# Altium schematic sheet Ribbon Interface.SchDoc("Ribbon Interface")
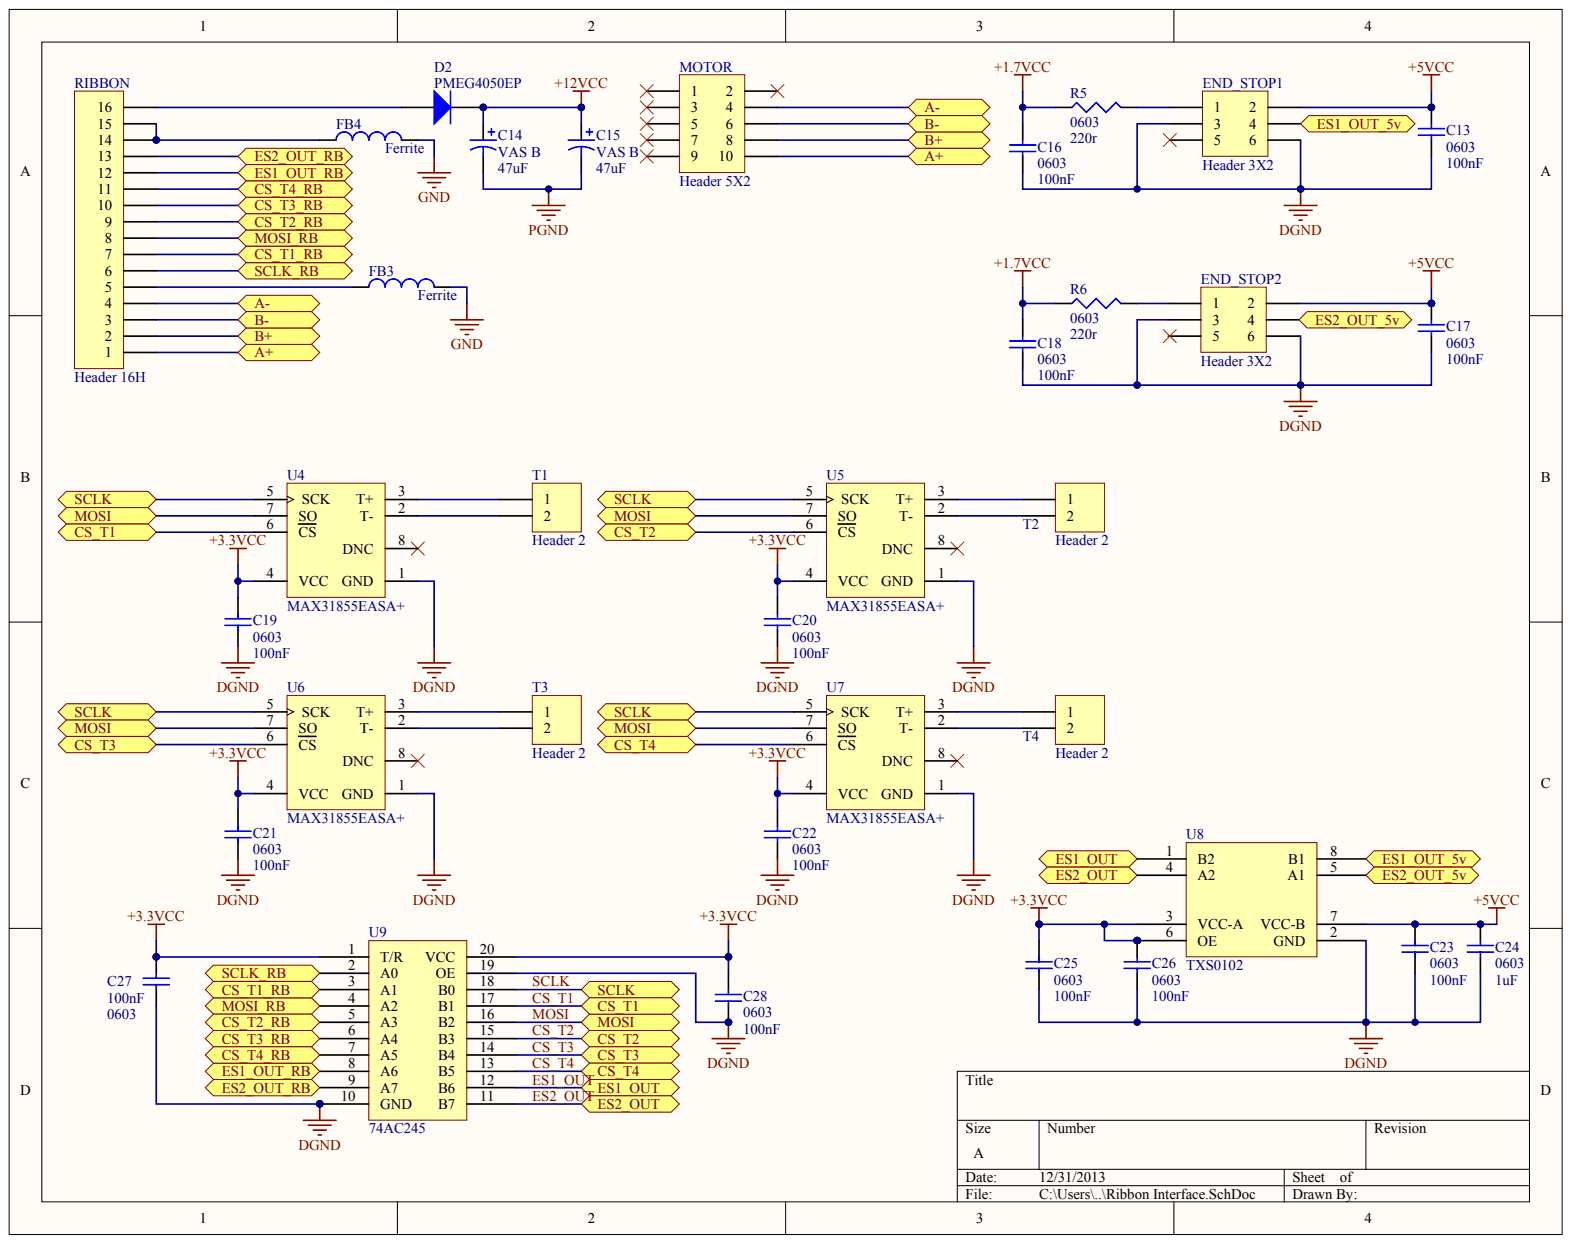
[diagram p0: Ribbon Interface.SchDoc]

## Parts
C13  0603  value 100nF
C14  VAS B  value 47uF
C15  VAS B  value 47uF
C16  0603  value 100nF
C17  0603  value 100nF
C18  0603  value 100nF
C19  0603  value 100nF
C20  0603  value 100nF
C21  0603  value 100nF
C22  0603  value 100nF
C23  0603  value 100nF
C24  0603  value 1uF
C25  0603  value 100nF
C26  0603  value 100nF
C27  0603  value 100nF
C28  0603  value 100nF
D2  PMEG4050EP
END_STOP1  Header 3X2
END_STOP2  Header 3X2
FB3  Ferrite
FB4  Ferrite
MOTOR  Header 5X2
R5  0603  value 220r
R6  0603  value 220r
RIBBON  Header 16H
T1  Header 2
T2  Header 2
T3  Header 2
T4  Header 2
U4  MAX31855EASA+
U5  MAX31855EASA+
U6  MAX31855EASA+
U7  MAX31855EASA+
U8  TXS0102
U9  74AC245

## Ports
A+, A-, B+, B-, CS_T1, CS_T1_RB, CS_T2, CS_T2_RB, CS_T3, CS_T3_RB, CS_T4, CS_T4_RB, ES1_OUT, ES1_OUT_5V, ES1_OUT_RB, ES2_OUT, ES2_OUT_5V, ES2_OUT_RB, MOSI, MOSI_RB, SCLK, SCLK_RB

## Nets
+1.7VCC: C16.2 C18.2 R5.1 R6.1
+3.3VCC: C19.2 C20.2 C21.2 C22.2 C25.2 C26.2 C27.2 C28.2 U4.4(VCC) U5.4(VCC) U6.4(VCC) U7.4(VCC) U8.3(VCC-A) U8.6(OE) U9.1(T/R) U9.20(VCC)
+5VCC: C13.2 C17.2 C23.2 C24.2 END_STOP1.2 END_STOP2.2 U8.7(VCC-B)
+12VCC: C14.1 C15.1 D2.1
DGND: C13.1 C16.1 C17.1 C18.1 C19.1 C20.1 C21.1 C22.1 C23.1 C24.1 C25.1 C26.1 C27.1 C28.1 END_STOP1.3 END_STOP1.6 END_STOP2.3 END_STOP2.6 U4.1(GND) U5.1(GND) U6.1(GND) U7.1(GND) U8.2(GND) U9.10(GND) U9.19(OE)
GND: FB3.2 FB4.2
PGND: C14.2 C15.2
CS_T1: U4.6(CS) U9.17(B1) ; port CS_T1
CS_T1_RB: RIBBON.7 U9.3(A1) ; port CS_T1_RB
CS_T2: U5.6(CS) U9.15(B3) ; port CS_T2
CS_T2_RB: RIBBON.9 U9.5(A3) ; port CS_T2_RB
CS_T3: U6.6(CS) U9.14(B4) ; port CS_T3
CS_T3_RB: RIBBON.10 U9.6(A4) ; port CS_T3_RB
CS_T4: U7.6(CS) U9.13(B5) ; port CS_T4
CS_T4_RB: RIBBON.11 U9.7(A5) ; port CS_T4_RB
ES1_OUT: U8.1(B2) U9.12(B6) ; port ES1_OUT
ES1_OUT_RB: RIBBON.12 U9.8(A6) ; port ES1_OUT_RB
ES2_OUT: U8.4(A2) U9.11(B7) ; port ES2_OUT
ES2_OUT_RB: RIBBON.13 U9.9(A7) ; port ES2_OUT_RB
MOSI: U4.7(SO) U5.7(SO) U6.7(SO) U7.7(SO) U9.16(B2) ; port MOSI
MOSI_RB: RIBBON.8 U9.4(A2) ; port MOSI_RB
SCLK: U4.5(SCK) U5.5(SCK) U6.5(SCK) U7.5(SCK) U9.18(B0) ; port SCLK
SCLK_RB: RIBBON.6 U9.2(A0) ; port SCLK_RB
NetD2_2: D2.2 RIBBON.16
NetEND_STOP1_1: END_STOP1.1 R5.2
NetEND_STOP1_4: END_STOP1.4 U8.8(B1) ; port ES1_OUT_5V
NetEND_STOP2_1: END_STOP2.1 R6.2
NetEND_STOP2_4: END_STOP2.4 U8.5(A1) ; port ES2_OUT_5V
NetFB3_1: FB3.1 RIBBON.5
NetFB4_1: FB4.1 RIBBON.14 RIBBON.15
NetMOTOR_4: MOTOR.4 RIBBON.4
NetMOTOR_6: MOTOR.6 RIBBON.3
NetMOTOR_8: MOTOR.8 RIBBON.2
NetMOTOR_10: MOTOR.10 RIBBON.1
NetT1_1: T1.1 U4.3(T+)
NetT1_2: T1.2 U4.2(T-)
NetT2_1: T2.1 U5.3(T+)
NetT2_2: T2.2 U5.2(T-)
NetT3_1: T3.1 U6.3(T+)
NetT3_2: T3.2 U6.2(T-)
NetT4_1: T4.1 U7.3(T+)
NetT4_2: T4.2 U7.2(T-)

Not connected: END_STOP1 5; END_STOP2 5; MOTOR 1 2 3 5 7 9; U4 8(DNC); U5 8(DNC); U6 8(DNC); U7 8(DNC)

## Library data of the parts
FB3 FB4 = (no symbol name): Code_IEC: 1005; Published: 8-Jun-2000; LatestRevisionDate: 17-Jul-2002; LatestRevisionNote: Re-released for DXP Platform.; PackageReference: 0402-A; Code_JEITA: 0402; Publisher: Altium Limited
R5 R6 = (no symbol name): Value: 220r; Published: 8-Jun-2000; LatestRevisionDate: 29-May-2009; LatestRevisionNote: IPC-7351 Footprint Added.; PackageReference: J1-0603; PackageDescription: Chip Resistor; Publisher: Altium Limited
U4-U7 = (no symbol name): Functions: Thermocouple-to-Digital Converter; Interface: SPI; Parasite Pwr.: No; DatasheetVersion: Rev. 2; PackageVersion: Rev. D, 10/2012; PackageReference: S8+4; PackageDescription: 8-Pin Small-Outline IC, Narrow, Body 3.9 x 4.9 mm, Pitch 1.27 mm; Supplier 1: Digi-Key; Supplier Part Number 1: MAX31855EASA+-ND; Supplier 2: Mouser; Supplier Part Number 2: 700-MAX31855EASA+; Generic Part Number: MAX31855; Mounting Technology: Surface Mount; Code_JEDEC: MS-012; PartNumber: MAX31855EASA+; ComponentLink1Description: Manufacturer URL; ComponentLink1URL: http://www.maximintegrated.com/; ComponentLink2Description: Datasheet; ComponentLink2URL: http://datasheets.maximintegrated.com/en/ds/MAX31855.pdf; ComponentLink3Description: Package Specification; ComponentLink3URL: http://pdfserv.maximintegrated.com/package_dwgs/21-0041.PDF
C13-C28 D2 END_STOP1 END_STOP2 MOTOR RIBBON T1-T4 U8 U9 = (no symbol name)
  C13 C16-C28: Published: 8-Jun-2000; LatestRevisionDate: 29-May-2009; LatestRevisionNote: IPC-7351 Footprint Added.; PackageReference: C1206; PackageDescription: Chip Capacitor
  C14 C15: Published: 8-Jun-2000; LatestRevisionDate: 17-Jul-2002; LatestRevisionNote: Re-released for DXP Platform.; PackageReference: RB7.6-15; PackageDescription: Polarized Capacitor (Radial); 2 Leads
  D2: Published: 8-Jun-2000; LatestRevisionDate: 17-Jul-2002; LatestRevisionNote: Re-released for DXP Platform.; PackageVersion: Sep-1996; PackageReference: SMC; PackageDescription: DO-214-AB/SMC; 2 C-Bend Leads; Body 7.9 x 5.9 mm, inc. leads (LxW); Code_JEDEC: DO-214-AB
  END_STOP1 END_STOP2 MOTOR RIBBON T1-T4: LatestRevisionDate: 17-Jul-2002; LatestRevisionNote: Re-released for DXP Platform.
  C13-C28 D2 END_STOP1 END_STOP2 MOTOR RIBBON T1-T4: Publisher: Altium Limited
